# Altium schematic sheet Center Console - LED Interface.SchDoc("Center Console - LED Interface")
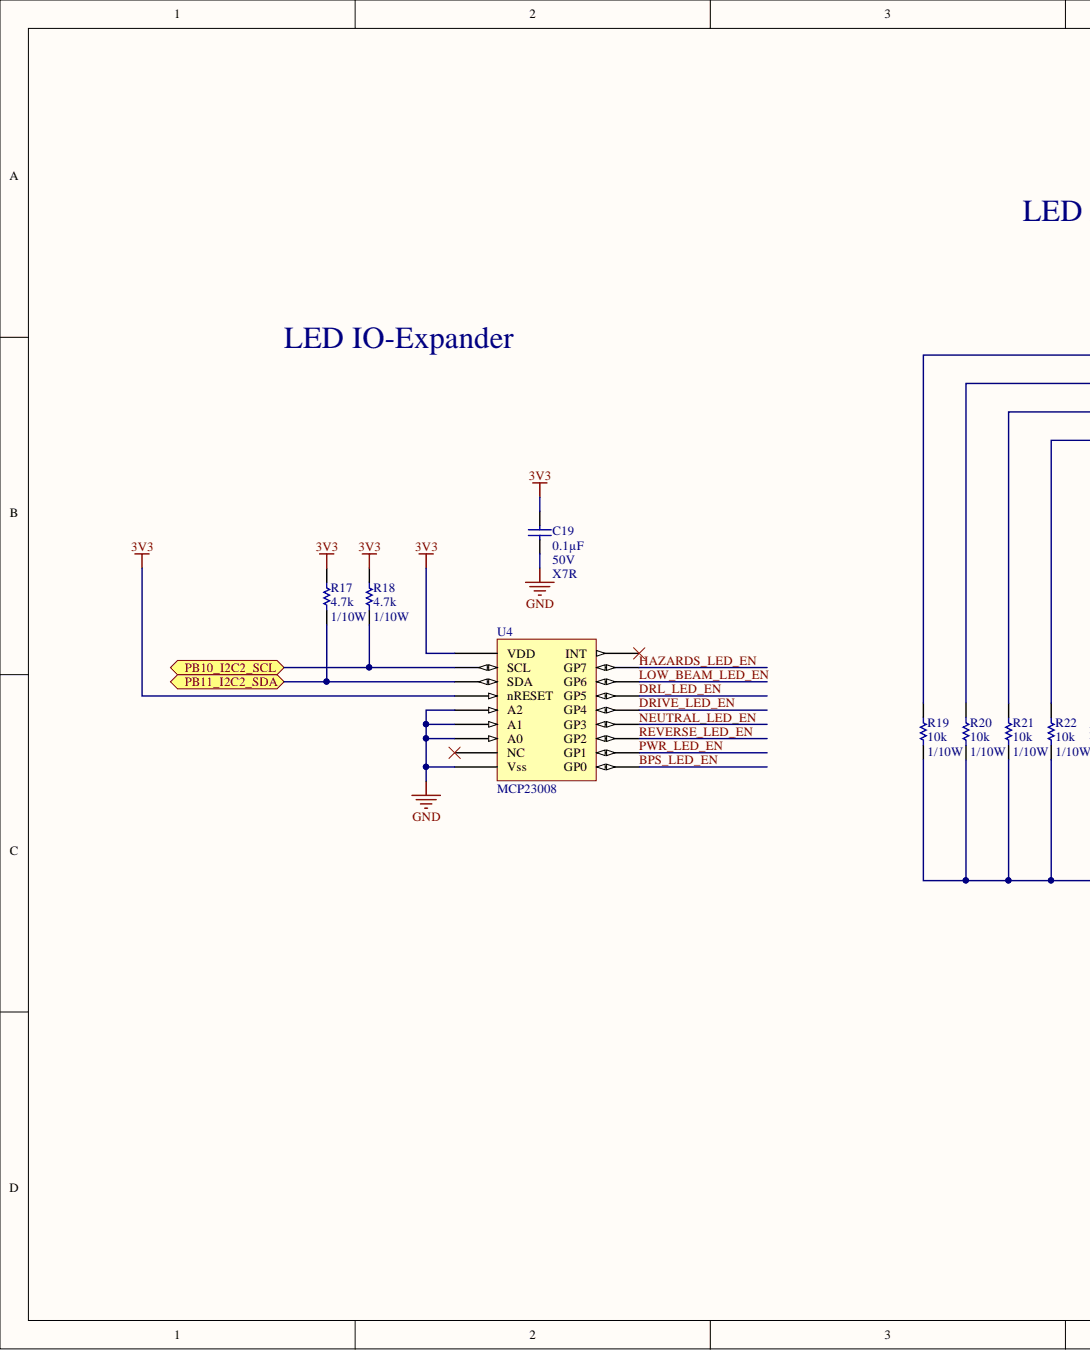
[diagram p0: Center Console - LED Interface.SchDoc, left]
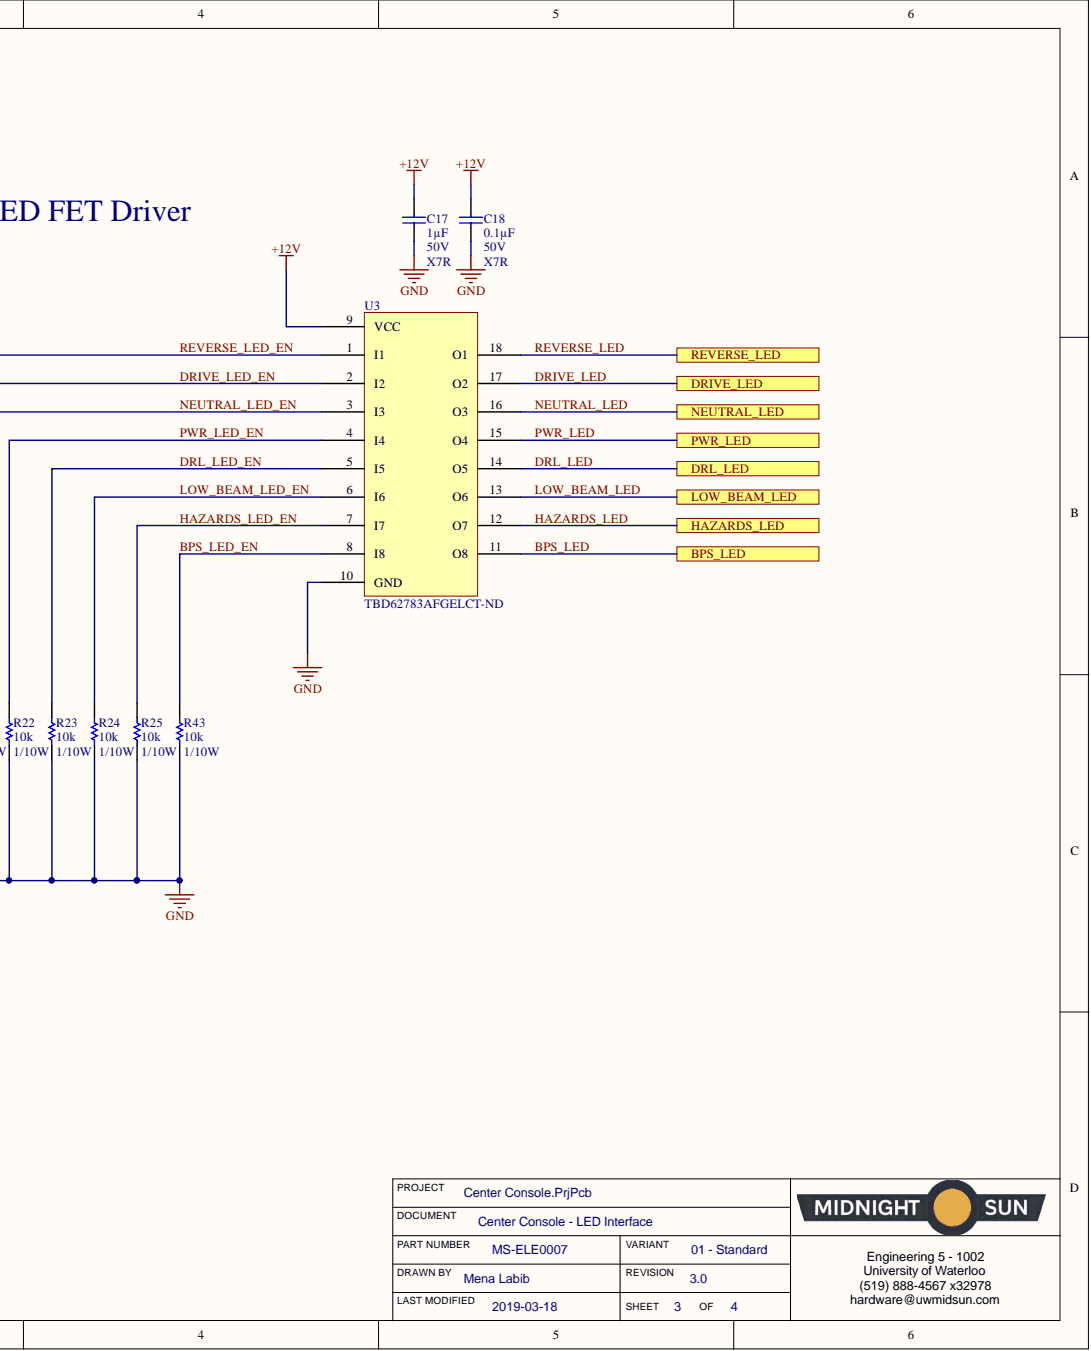
[diagram p1: Center Console - LED Interface.SchDoc, right]

## Parts
C17  Voltage: 50V  Temperature Coefficient: X7R  Capacitance: 1µF  [CAP, 0603]  (hidden comment: CAP CER 1UF 50V 10% X7R 0603)
C18  Voltage: 50V  Temperature Coefficient: X7R  Capacitance: 0.1µF  [CAP, 0603]  (hidden comment: CAP CER 0.1UF 50V 10% X7R 0603)
C19  Voltage: 50V  Temperature Coefficient: X7R  Capacitance: 0.1µF  [CAP, 0603]  (hidden comment: CAP CER 0.1UF 50V 10% X7R 0603)
R17  Resistance (Ohms): 4.7k  Power (Watts): 1/10W  [RES, 0603]  (hidden comment: RES 4.7K OHM 1% 1/10W 0603)
R18  Resistance (Ohms): 4.7k  Power (Watts): 1/10W  [RES, 0603]  (hidden comment: RES 4.7K OHM 1% 1/10W 0603)
R19  Resistance: 10k  Power: 1/10W  [RES, 0603]  (hidden comment: RES 10K OHM 1% 1/10W 0603)
R20  Resistance: 10k  Power: 1/10W  [RES, 0603]  (hidden comment: RES 10K OHM 1% 1/10W 0603)
R21  Resistance: 10k  Power: 1/10W  [RES, 0603]  (hidden comment: RES 10K OHM 1% 1/10W 0603)
R22  Resistance: 10k  Power: 1/10W  [RES, 0603]  (hidden comment: RES 10K OHM 1% 1/10W 0603)
R23  Resistance: 10k  Power: 1/10W  [RES, 0603]  (hidden comment: RES 10K OHM 1% 1/10W 0603)
R24  Resistance: 10k  Power: 1/10W  [RES, 0603]  (hidden comment: RES 10K OHM 1% 1/10W 0603)
R25  Resistance: 10k  Power: 1/10W  [RES, 0603]  (hidden comment: RES 10K OHM 1% 1/10W 0603)
R43  Resistance: 10k  Power: 1/10W  [RES, 0603]  (hidden comment: RES 10K OHM 1% 1/10W 0603)
U3  Supplier Part Number 1: TBD62783AFGELCT-ND  [IC LOAD SWITCH 8CH 0.5A 18-SSOP]  (hidden comment: IC LOAD SWITCH 8CH 0.5A 18SOP)
U4  MCP23008  [IC 18SOIC]

## Ports
BPS_LED, DRIVE_LED, DRL_LED, HAZARDS_LED, LOW_BEAM_LED, NEUTRAL_LED, PB10_I2C2_SCL, PB11_I2C2_SDA, PWR_LED, REVERSE_LED

## Nets
3V3: C19.1 R17.1 R18.1 U4.6(nRESET) U4.18(VDD)
+12V: C17.1 C18.1 U3.9(VCC)
GND: C17.2 C18.2 C19.2 R19.2 R20.2 R21.2 R22.2 R23.2 R24.2 R25.2 R43.2 U3.10(GND) U4.3(A2) U4.4(A1) U4.5(A0) U4.9(Vss)
BPS_LED: U3.11(O8) ; port BPS_LED
BPS_LED_EN_3: R43.1 U3.8(I8) U4.10(GP0)  [also labelled BPS_LED_EN]
DRIVE_LED: U3.17(O2) ; port DRIVE_LED
DRIVE_LED_EN_3: R20.1 U3.2(I2) U4.14(GP4)  [also labelled DRIVE_LED_EN]
DRL_LED: U3.14(O5) ; port DRL_LED
DRL_LED_EN_3: R23.1 U3.5(I5) U4.15(GP5)  [also labelled DRL_LED_EN]
HAZARDS_LED: U3.12(O7) ; port HAZARDS_LED
HAZARDS_LED_EN_3: R25.1 U3.7(I7) U4.17(GP7)  [also labelled HAZARDS_LED_EN]
LOW_BEAM_LED: U3.13(O6) ; port LOW_BEAM_LED
LOW_BEAM_LED_EN_3: R24.1 U3.6(I6) U4.16(GP6)  [also labelled LOW_BEAM_LED_EN]
NEUTRAL_LED: U3.16(O3) ; port NEUTRAL_LED
NEUTRAL_LED_EN_3: R21.1 U3.3(I3) U4.13(GP3)  [also labelled NEUTRAL_LED_EN]
PB10_I2C2_SCL: R18.2 U4.1(SCL) ; port PB10_I2C2_SCL
PB11_I2C2_SDA: R17.2 U4.2(SDA) ; port PB11_I2C2_SDA
PWR_LED: U3.15(O4) ; port PWR_LED
PWR_LED_EN_3: R22.1 U3.4(I4) U4.11(GP1)  [also labelled PWR_LED_EN]
REVERSE_LED: U3.18(O1) ; port REVERSE_LED
REVERSE_LED_EN_3: R19.1 U3.1(I1) U4.12(GP2)  [also labelled REVERSE_LED_EN]
NetU4_7: U4.7(NC)
NetU4_8: U4.8(INT)

## Library data of the parts
C17 = CAP CER 1UF 50V 10% X7R 0603: 1µF ±10% 50V Ceramic Capacitor X7R 0603 (1608 Metric); Manufacturer Part Number 1: UMK107AB7105KA-T; Manufacturer 1: Taiyo Yuden; Tolerance: ±10%; Thickness (Max): 0.037" (0.95mm); Supplier Part Number 1: 587-3247-1-ND; Supplier 1: Digi-Key; Size / Dimension: 0.063" L x 0.031" W (1.60mm x 0.80mm); Ratings: -; Part Status: Active; Packaging: Cut Tape (CT); Package / Case: 0603 (1608 Metric); Operating Temperature: -55°C ~ 125°C; Mounting Type: Surface Mount, MLCC; Lead Style: -; Lead Spacing: -; Height - Seated (Max): -; ComponentLink1URL: http://www.yuden.co.jp/productdata/catalog/en/mlcc_all_e.pdf; ComponentLink1Description: http://www.yuden.co.jp/productdata/catalog/en/mlcc_all_e.pdf; Component Kind: Standard; Library Name: Schematic Diagrams.SchLib
C18 C19 = CAP CER 0.1UF 50V 10% X7R 0603: 0.10µF ±10% 50V Ceramic Capacitor X7R 0603 (1608 Metric); Manufacturer Part Number 1: 06035C104KAT2A; Manufacturer 1: AVX Corporation; Tolerance: ±10%; Thickness (Max): 0.035" (0.90mm); Supplier Part Number 1: 478-5052-1-ND; Supplier 1: Digi-Key; Size / Dimension: 0.063" L x 0.032" W (1.60mm x 0.81mm); Ratings: -; Part Status: Active; Packaging: Cut Tape (CT); Package / Case: 0603 (1608 Metric); Operating Temperature: -55°C ~ 125°C; Mounting Type: Surface Mount, MLCC; Lead Style: -; Lead Spacing: -; Height - Seated (Max): -; ComponentLink1URL: http://datasheets.avx.com/X7RDielectric.pdf; ComponentLink1Description: http://datasheets.avx.com/X7RDielectric.pdf; Component Kind: Standard; Library Name: Schematic Diagrams.SchLib
R17 R18 = RES 4.7K OHM 1% 1/10W 0603: 4.7k Ohm ±1% 0.1W, 1/10W Chip Resistor 0603 (1608 Metric) Moisture Resistant Thick Film; Manufacturer Part Number 1: RC0603FR-074K7L; Manufacturer 1: Yageo; Temperature Coefficient: ±100ppm/°C; Description 1: RES SMD 4.7K OHM 1% 1/10W 0603; Tolerance: ±1%; Supplier Part Number 1: 311-4.70KHRCT-ND; Supplier Device Package: 0603; Supplier 1: Digi-Key; Stock 1: 3487566; Size / Dimension: 0.063" L x 0.031" W (1.60mm x 0.80mm); RoHS: RoHS Compliant; Pricing 1: 1=0.16, 10=0.022, 100=0.0089, 1000=0.00402, 2500=0.00349 (CAD); Part Status: Active; Packaging: Cut Tape (CT); Package / Case: 0603 (1608 Metric); Operating Temperature: -55°C ~ 155°C; Height - Seated (Max): 0.022" (0.55mm); Features: Moisture Resistant; Failure Rate: -; Composition: Thick Film; ComponentLink1URL: http://www.yageo.com/documents/recent/PYu-RC_Group_51_RoHS_L_7.pdf; ComponentLink1Description: http://www.yageo.com/documents/recent/PYu-RC_Group_51_RoHS_L_7.pdf; Component Kind: Standard; Category: Resistors; Library Name: Schematic Diagrams.SchLib
R19-R25 R43 = RES 10K OHM 1% 1/10W 0603: RES SMD 10K OHM 1% 1/10W 0603; Manufacturer Part Number 1: RC0603FR-0710KL; Manufacturer 1: Yageo; Temperature Coefficient: ±100ppm/°C; Tolerance: ±1%; Supplier Part Number 1: 311-10.0KHRCT-ND; Supplier Device Package: 0603; Supplier 1: Digi-Key; Size / Dimension: 0.063" L x 0.031" W (1.60mm x 0.80mm); Part Status: Active; Packaging: Cut Tape (CT); Package / Case: 0603 (1608 Metric); Operating Temperature: -55°C ~ 155°C; Height - Seated (Max): 0.022" (0.55mm); Failure Rate: -; Composition: Thick Film; ComponentLink1URL: http://www.yageo.com/documents/recent/PYu-RC_Group_51_RoHS_L_7.pdf; ComponentLink1Description: http://www.yageo.com/documents/recent/PYu-RC_Group_51_RoHS_L_7.pdf; Component Kind: Standard; Library Name: Schematic Diagrams.SchLib
U3 = IC LOAD SWITCH 8CH 0.5A 18SOP: IC LOAD SWITCH 8CHANNEL 0.5A 18SOP; Manufacturer Part Number: TBD62783AFG,EL; Manufacturer: Toshiba; Output Configuration: High Side; Number of Outputs: 8; Max Output Current: 500mA; Interface: On/Off; Supplier 1: Digi-Key; Stock 1: 8776; RoHS: Yes; Pricing 1: 1 = 1.15000, 10 = 1.02200, 25 = 0.92280, 100 = 0.80750, 250 = 0.70864, 500 = 0.62624 (USD); Packaging: Cut Tape; Number of Pins: 18; Min Operating Temperature: -40°C; Max Operating Temperature: 85°C; Component Kind: Standard; Case/Package: SOIC; Library Name: Schematic Diagrams.SchLib
U4 = IC I/O EXPANDER I2C 8B 18SOIC: MCP23008 I/O Expander 8B+1Int 18P SOIC; Manufacturer Part Number: MCP23008T-E/SO; Manufacturer: Microchip Technology; Voltage - Supply: 1.8 V ~ 5.5 V; Output Type: Push-Pull; Number of I/O: 8; Interrupt Output: Yes; Interface: I²C; Current - Output Source/Sink: 25mA; Supplier Part Number 1: MCP23008T-E/SOCT-ND; Supplier Device Package: 18-SOIC; Supplier 1: Digi-Key; Stock 1: 5607; RoHS: RoHS Compliant; Pricing 1: 1=1.62, 25=1.3548, 100=1.2431 (CAD); Part Status: Active; Packaging: Cut Tape (CT); Package / Case: 18-SOIC (0.295", 7.50mm Width); Operating Temperature: -40°C ~ 125°C; Mounting Type: Surface Mount; Features: POR; ComponentLink1URL: http://www.microchip.com/mymicrochip/filehandler.aspx?ddocname=en021920; ComponentLink1Description: http://www.microchip.com/mymicrochip/filehandler.aspx?ddocname=en021920; Component Kind: Standard; Category: Integrated Circuits (ICs); Library Name: Schematic Diagrams.SchLib
